# Altium schematic sheet NB_Bagde.SchDoc(NB_Bagde)
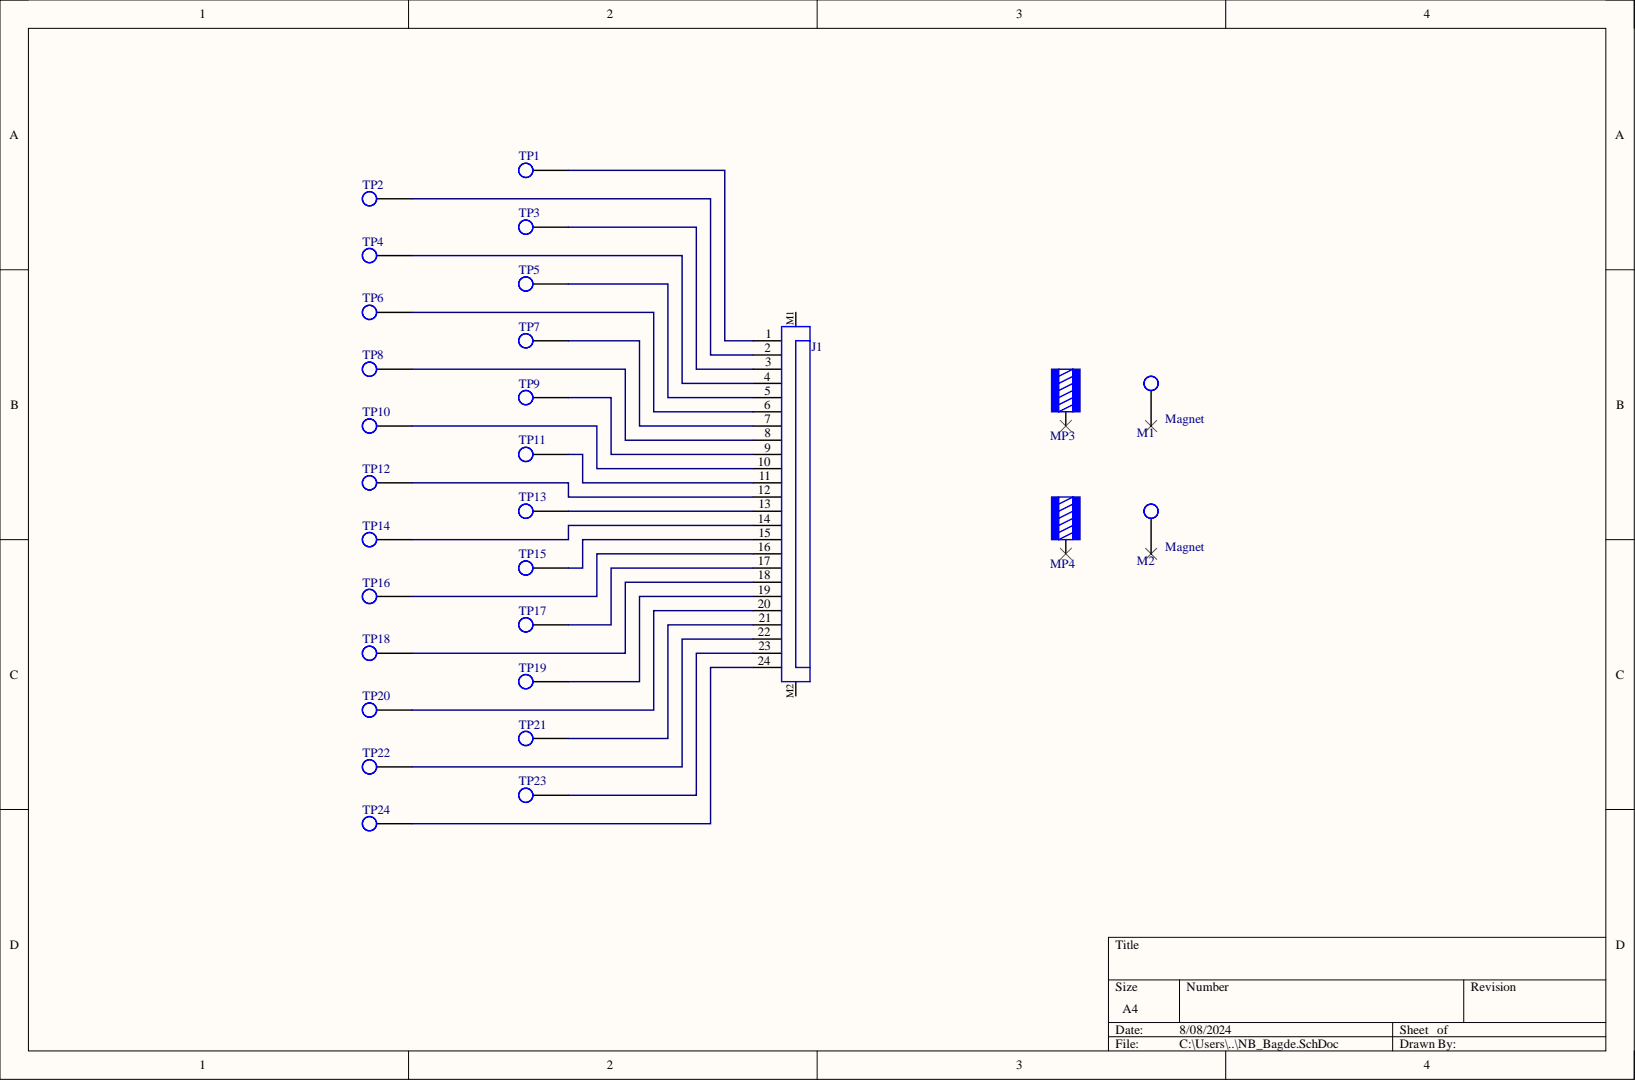
[diagram p0: NB_Bagde.SchDoc]

## Parts
J1  687124182122  [687124182122]  (value not drawn)
M1  Magnet  [Magnet]
M2  Magnet  [Magnet]
MP3  M3  [7466303]  (value not drawn)
MP4  M3  [7466303]  (value not drawn)
TP1  Test_Point  [Test_Point]  (value not drawn)  (no BOM)
TP2  Test_Point  [Test_Point]  (value not drawn)  (no BOM)
TP3  Test_Point  [Test_Point]  (value not drawn)  (no BOM)
TP4  Test_Point  [Test_Point]  (value not drawn)  (no BOM)
TP5  Test_Point  [Test_Point]  (value not drawn)  (no BOM)
TP6  Test_Point  [Test_Point]  (value not drawn)  (no BOM)
TP7  Test_Point  [Test_Point]  (value not drawn)  (no BOM)
TP8  Test_Point  [Test_Point]  (value not drawn)  (no BOM)
TP9  Test_Point  [Test_Point]  (value not drawn)  (no BOM)
TP10  Test_Point  [Test_Point]  (value not drawn)  (no BOM)
TP11  Test_Point  [Test_Point]  (value not drawn)  (no BOM)
TP12  Test_Point  [Test_Point]  (value not drawn)  (no BOM)
TP13  Test_Point  [Test_Point]  (value not drawn)  (no BOM)
TP14  Test_Point  [Test_Point]  (value not drawn)  (no BOM)
TP15  Test_Point  [Test_Point]  (value not drawn)  (no BOM)
TP16  Test_Point  [Test_Point]  (value not drawn)  (no BOM)
TP17  Test_Point  [Test_Point]  (value not drawn)  (no BOM)
TP18  Test_Point  [Test_Point]  (value not drawn)  (no BOM)
TP19  Test_Point  [Test_Point]  (value not drawn)  (no BOM)
TP20  Test_Point  [Test_Point]  (value not drawn)  (no BOM)
TP21  Test_Point  [Test_Point]  (value not drawn)  (no BOM)
TP22  Test_Point  [Test_Point]  (value not drawn)  (no BOM)
TP23  Test_Point  [Test_Point]  (value not drawn)  (no BOM)
TP24  Test_Point  [Test_Point]  (value not drawn)  (no BOM)

## Nets
NetJ1_1: J1.1 TP1.1
NetJ1_2: J1.2 TP2.1
NetJ1_3: J1.3 TP3.1
NetJ1_4: J1.4 TP4.1
NetJ1_5: J1.5 TP5.1
NetJ1_6: J1.6 TP6.1
NetJ1_7: J1.7 TP7.1
NetJ1_8: J1.8 TP8.1
NetJ1_9: J1.9 TP9.1
NetJ1_10: J1.10 TP10.1
NetJ1_11: J1.11 TP11.1
NetJ1_12: J1.12 TP12.1
NetJ1_13: J1.13 TP13.1
NetJ1_14: J1.14 TP14.1
NetJ1_15: J1.15 TP15.1
NetJ1_16: J1.16 TP16.1
NetJ1_17: J1.17 TP17.1
NetJ1_18: J1.18 TP18.1
NetJ1_19: J1.19 TP19.1
NetJ1_20: J1.20 TP20.1
NetJ1_21: J1.21 TP21.1
NetJ1_22: J1.22 TP22.1
NetJ1_23: J1.23 TP23.1
NetJ1_24: J1.24 TP24.1

Not connected: J1 M1 M2; M1 1; M2 1; MP3 1; MP4 1

## Library data of the parts
J1 = 687124182122: WR-FPC SMT ZIF Horizontal Dual Contact, pitch 0.5mm, Horizontal, 24pins; Manufacturer: Wurth Elektronik; Contact Resistance: 30mΩ; Rated Current: 0.5A; Working Voltage: 50VAC; Category: FPC Connectors; Component Kind: Standard; ComponentLink1Description: Website Link; ComponentLink1URL: https://www.we-online.com/redexpert/article/687124182122?ad; ComponentLink2Description: Datasheet Link; ComponentLink2URL: https://www.we-online.com/redexpert/spec/687124182122?ad; Height: 0.96mm; Length: 14mm; Library Name: Schlib.SchLib; Manufacturer Part Number: 687124182122; Match Code: WR-FPC; Mount: Surface Mount; Number of Pins: 24; Operating Temperature Max: 85°C; Operating Temperature Min: -55°C; Orientation: Horizontal; Packaging: Tape and Reel; Pitch: 0.5mm; Width: 3.7mm
M1 M2 = Magnet: Magnet 3x2mm; Component Kind: Standard; Library Name: Schlib.SchLib
MP3 MP4 = 7466303R: WP-SMRA REDCUBE SMT Right Angled without pins, M3, 50A; Manufacturer: Wurth Elektronik; Surface: Tin; Category: REDCUBE SMT; Component Kind: Standard; ComponentLink1Description: Website Link; ComponentLink1URL: https://www.we-online.com/redexpert/article/7466303R?ad; ComponentLink2Description: Datasheet Link; ComponentLink2URL: https://www.we-online.com/redexpert/spec/7466303R?ad; Height: 5mm; Library Name: Schlib.SchLib; Manufacturer Part Number: 7466303R; Match Code: WP-SMRA; Material: Brass; Mount: Surface Mount; Operating Temperature Max: 150°C; Operating Temperature Min: -55°C; Packaging: Tape and Reel; Rated Current: 50A; Thread Size: M3
TP1-TP24 = Test_Point: Test Point; Library Name: Schlib.SchLib
